# Altium schematic sheet watchdog.SchDoc
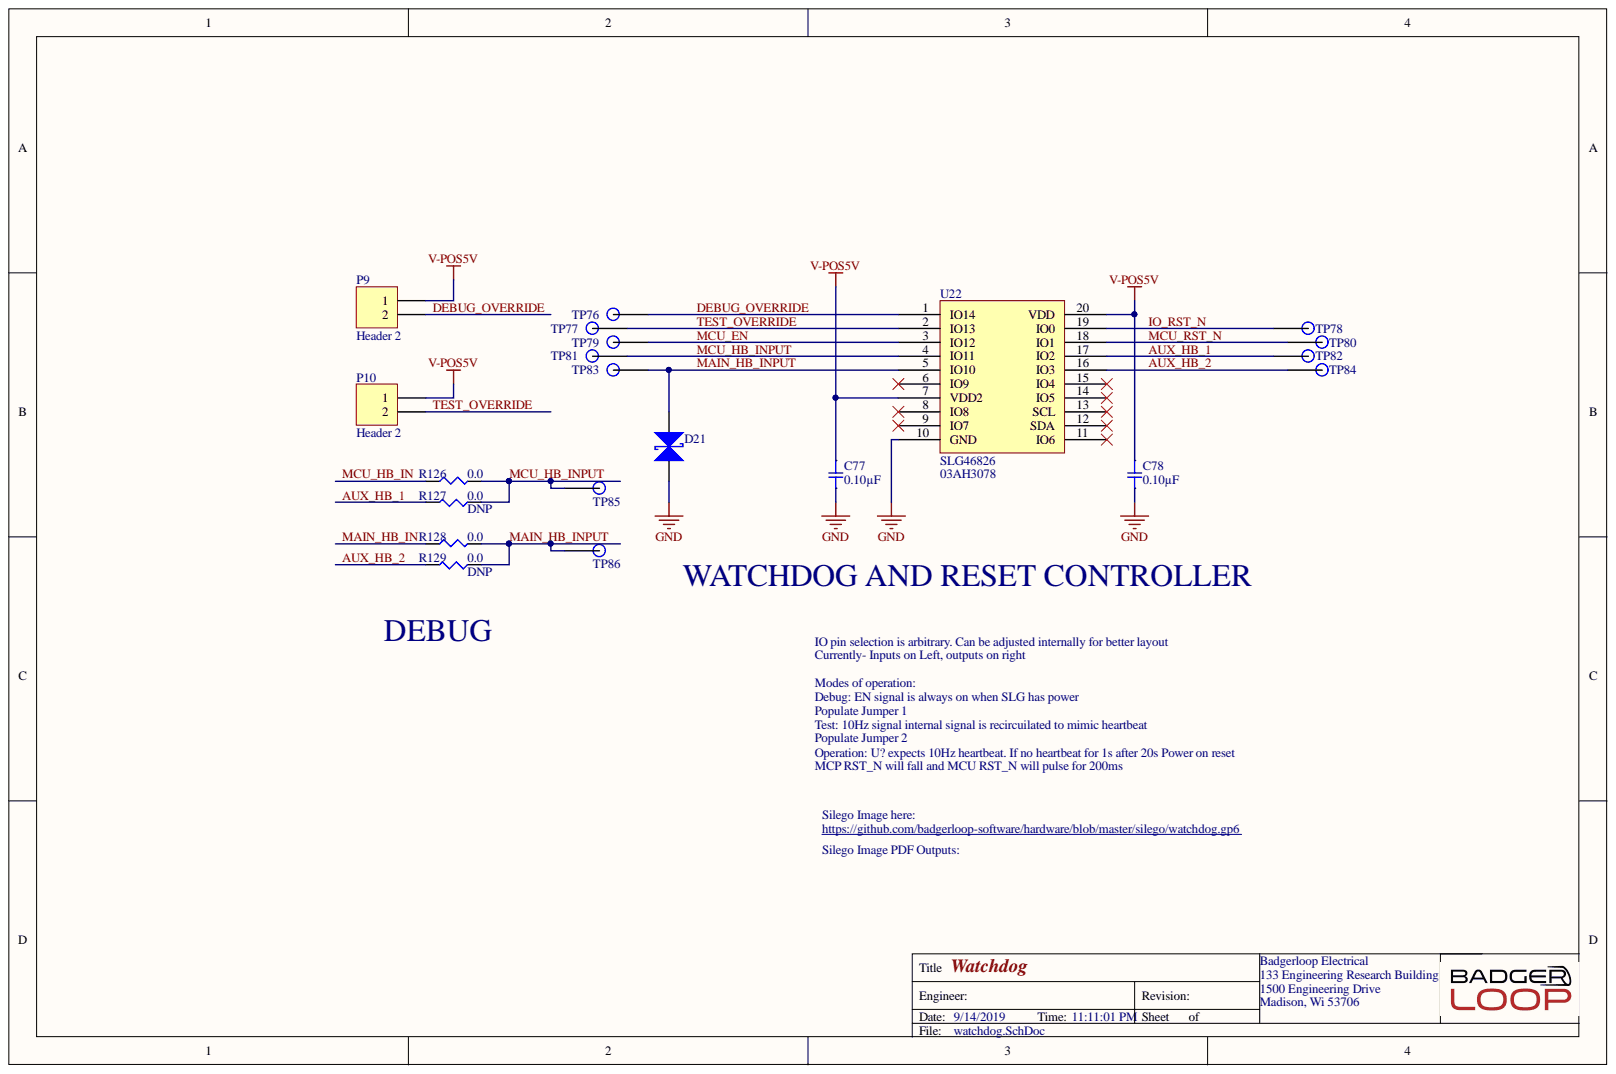
[diagram p0: watchdog.SchDoc]

## Parts
C77  0.10µF  [SMD-0805C]
C78  0.10µF  [SMD-0805C]
D21  SMBJ15A  [DIOM4436X26N]  (value not drawn)
P9  Header 2  [Pin Header 1x2 TH Pitch 2.54mm]
P10  Header 2  [Pin Header 1x2 TH Pitch 2.54mm]
R126  0.0  [SMD-0805-RES]
R127  0.0  [SMD-0805-RES]
R128  0.0  [SMD-0805-RES]
R129  0.0  [SMD-0805-RES]
TP76  TP  [Test Point]
TP77  TP  [Test Point]
TP78  TP  [Test Point]
TP79  TP  [Test Point]
TP80  TP  [Test Point]
TP81  TP  [Test Point]
TP82  TP  [Test Point]
TP83  TP  [Test Point]
TP84  TP  [Test Point]
TP85  TP  [Test Point]
TP86  TP  [Test Point]
U22  SLG46826  Supplier Part Number 1: 03AH3078  [SOP65P640X175-20N]

## Nets
GND: C77.2 C78.2 D21.1 U22.10(GND)
V-POS5V: C77.1 C78.1 P9.1 P10.1 U22.7(VDD2) U22.20(VDD)
AUX_HB_1: R127.2 TP82.1 U22.17(IO2)
AUX_HB_2: R129.2 TP84.1 U22.16(IO3)
DEBUG_OVERRIDE: P9.2 TP76.1 U22.1(IO14)
IO_RST_N: TP78.1 U22.19(IO0)
MAIN_HB_IN: R128.1
MAIN_HB_INPUT: D21.2 R128.2 R129.1 TP83.1 TP86.1 U22.5(IO10)
MCU_EN: TP79.1 U22.3(IO12)
MCU_HB_IN: R126.1
MCU_HB_INPUT: R126.2 R127.1 TP81.1 TP85.1 U22.4(IO11)
MCU_RST_N: TP80.1 U22.18(IO1)
TEST_OVERRIDE: P10.2 TP77.1 U22.2(IO13)

Not connected: U22 6(IO9) 8(IO8) 9(IO7) 11(IO6) 12(SDA) 13(SCL) 14(IO5) 15(IO4)

## Library data of the parts
C77 C78 = GRM219R71E104KA01D: CAP CER 0.1UF 25V X7R 0805; Manufacturer: Murata Electronics North America; @ qty: 0; Minimum Quantity: 1; Tolerance: ±10%; Unit Price (USD): 0.12; Applications: General Purpose; Component Kind: Standard; Datasheets: http://www.murata.com/~/media/webrenewal/support/library/catalog/products/capacitor/mlcc/c02e.pdf; Digi-Key Part Number: 490-12754-1-ND; Factory Stock: 0; Features: -; Height - Seated (Max): -; Image: //media.digikey.com/Renders/Murata%20Renders/0805%20(2012%20Metric)%20.85mm.jpg; Lead Spacing: -; Lead Style: -; Library Name: capacitors.SchLib; Mounting Type: Surface Mount, MLCC; Operating Temperature: -55°C ~ 125°C; Package / Case: 0805 (2012 Metric); Packaging: Cut Tape (CT); Part Number: GRM219R71E104KA01D; Part Status: Active; Quantity Available: 8594; Ratings: -; Series: GRM; Size / Dimension: 0.079" L x 0.049" W (2.00mm x 1.25mm); Temperature Coefficient: X7R; Thickness (Max): 0.037" (0.95mm); Value: 0.10µF; Voltage: 25V
D21 = SMBJ15A: TVS DIODE 15V 24.4V DO214AA; Manufacturer: Littelfuse; Breakdown Voltage: 16.7V; Direction: Unidirectional; Element Configuration: Single; Leakage Current: 1uA; Max Breakdown Voltage: 18.5V; Max Power Dissipation: 5W; Max Surge Current: 24.6A; Number of Channels: 1; Peak Pulse Power: 600W; Power Line Protection: No; Test Current: 1mA; Case/Package: SMB; Clamping Voltage: 24.4V; Component Kind: Standard; ComponentLink1Description: https://datasheet.ciiva.com/34301/1078555-34301957.pdf?src-supplier=Jameco; ComponentLink1URL: https://datasheet.ciiva.com/34301/1078555-34301957.pdf?src-supplier=Jameco; ComponentLink2Description: https://datasheet.ciiva.com/25624/0900766b810f4eeb-25624826.pdf?src-supplier=RSComponents; ComponentLink2URL: https://datasheet.ciiva.com/25624/0900766b810f4eeb-25624826.pdf?src-supplier=RSComponents; ComponentLink3Description: https://datasheet.ciiva.com/46385/13057-46385079.pdf?src-supplier=Element14; ComponentLink3URL: https://datasheet.ciiva.com/46385/13057-46385079.pdf?src-supplier=Element14; ComponentLink4Description: https://datasheet.ciiva.com/331/littelfuse-tvs-diode-smbj-datasheet-pdf-331176.pdf?src-supplier=Digi-Key; ComponentLink4URL: https://datasheet.ciiva.com/331/littelfuse-tvs-diode-smbj-datasheet-pdf-331176.pdf?src-supplier=Digi-Key; ComponentLink5Description: https://datasheet.ciiva.com/331/littelfuse-tvs-diode-smbj-datasheet-pdf-331177.pdf?src-supplier=Digi-Key; ComponentLink5URL: https://datasheet.ciiva.com/331/littelfuse-tvs-diode-smbj-datasheet-pdf-331177.pdf?src-supplier=Digi-Key; ComponentLink6Description: https://datasheet.ciiva.com/331/littelfuse-tvs-diode-smbj-datasheet-pdf-331178.pdf?src-supplier=Digi-Key; ComponentLink6URL: https://datasheet.ciiva.com/331/littelfuse-tvs-diode-smbj-datasheet-pdf-331178.pdf?src-supplier=Digi-Key; Composition: Zener; Height: 2.237mm; Lead Free: Lead Free; Length: 4.57mm; Library Name: misc.SchLib; Manufacturer Part Number: SMBJ15A; Max Operating Temperature: 150°C; Max Reverse Leakage Current: 1uA; Min Breakdown Voltage: 16.7V; Min Operating Temperature: -65°C; Mount: Surface Mount; Number of Elements: 1; Number of Pins: 2; Number of Unidirectional Channels: 1; Operating Supply Voltage: 15V; Package Quantity: 3000; Packaging: Tape and Reel; Peak Pulse Current: 24.6A; Polarity: Unidirectional; Power Dissipation: 600W; Pricing 1: 1=0.45000, 10=0.33900, 25=0.30520, 100=0.21090, 250=0.17760, 500=0.14430, 1000=0.11100 (USD); Radiation Hardening: No; REACH SVHC: Unknown; Reverse Breakdown Voltage: 16.7V; Reverse Standoff Voltage: 15V; RoHS: Yes; Stock 1: 73945; Supplier 1: Digi-Key; Supplier Part Number 1: SMBJ15ALFCT-ND; Termination: SMD/SMT; Voltage Rating (DC): 15V; Width: 3.94mm
P9 P10 = Header 2: Header, 2-Pin; Component Kind: Standard; LatestRevisionDate: 17-Jul-2002; LatestRevisionNote: Re-released for DXP Platform.; Library Name: connector.SchLib; Signal Integrity: Connector; Publisher: Altium Limited
R126-R129 = ERJ-6GEY0R00V: RES SMD 0.0 OHM JUMPER 1/8W 0805; Manufacturer: Panasonic Electronic Components; @ qty: 1500; Factory Stock: 0; Minimum Quantity: 1; Power (Watts): 0.125W, 1/8W; Unit Price (USD): 0.00544; Component Kind: Standard; Composition: Thick Film; Datasheets: http://industrial.panasonic.com/www-cgi/jvcr13pz.cgi?E+PZ+3+AOA0001+ERJ6GEY0R00V+7+WW; Digi-Key Part Number: P0.0ACT-ND; Failure Rate: -; Features: Automotive AEC-Q200; Height - Seated (Max): 0.028" (0.70mm); Image: //media.digikey.com/Renders/Panasonic%20Renders/ERJ-0805%20Pkg.jpg; Library Name: resistors.SchLib; Number of Terminations: 2; Operating Temperature: -55°C ~ 155°C; Package / Case: 0805 (2012 Metric); Packaging: Cut Tape (CT); Part Number: ERJ-6GEY0R00V; Part Status: Active; Quantity Available: 1597627; Series: ERJ; Size / Dimension: 0.079" L x 0.049" W (2.00mm x 1.25mm); Supplier Device Package: 0805; Temperature Coefficient: -; Tolerance: Jumper; Value: 0.0
  R127 R129: DNP: DNP
TP76-TP86 = TP: SMD TEST POINT; Component Kind: Standard; Library Name: misc.SchLib
U22 = SLG46826: GreenPAK Programmable Mixed Signal Matrix with In-System Programmability; Manufacturer: Dialog Semiconductor; Manufacturer Part Number: SLG46826V-SKT; Component Kind: Standard; Library Name: ic.SchLib; Pricing 1: 1=45.65000, 5=44.43000, 10=43.15000, 25=42.51000, 50=41.89000, 100=39.38000 (USD); RoHS: No; Stock 1: 4; Supplier 1: Newark
